# Altium schematic sheet power_supply.SchDoc
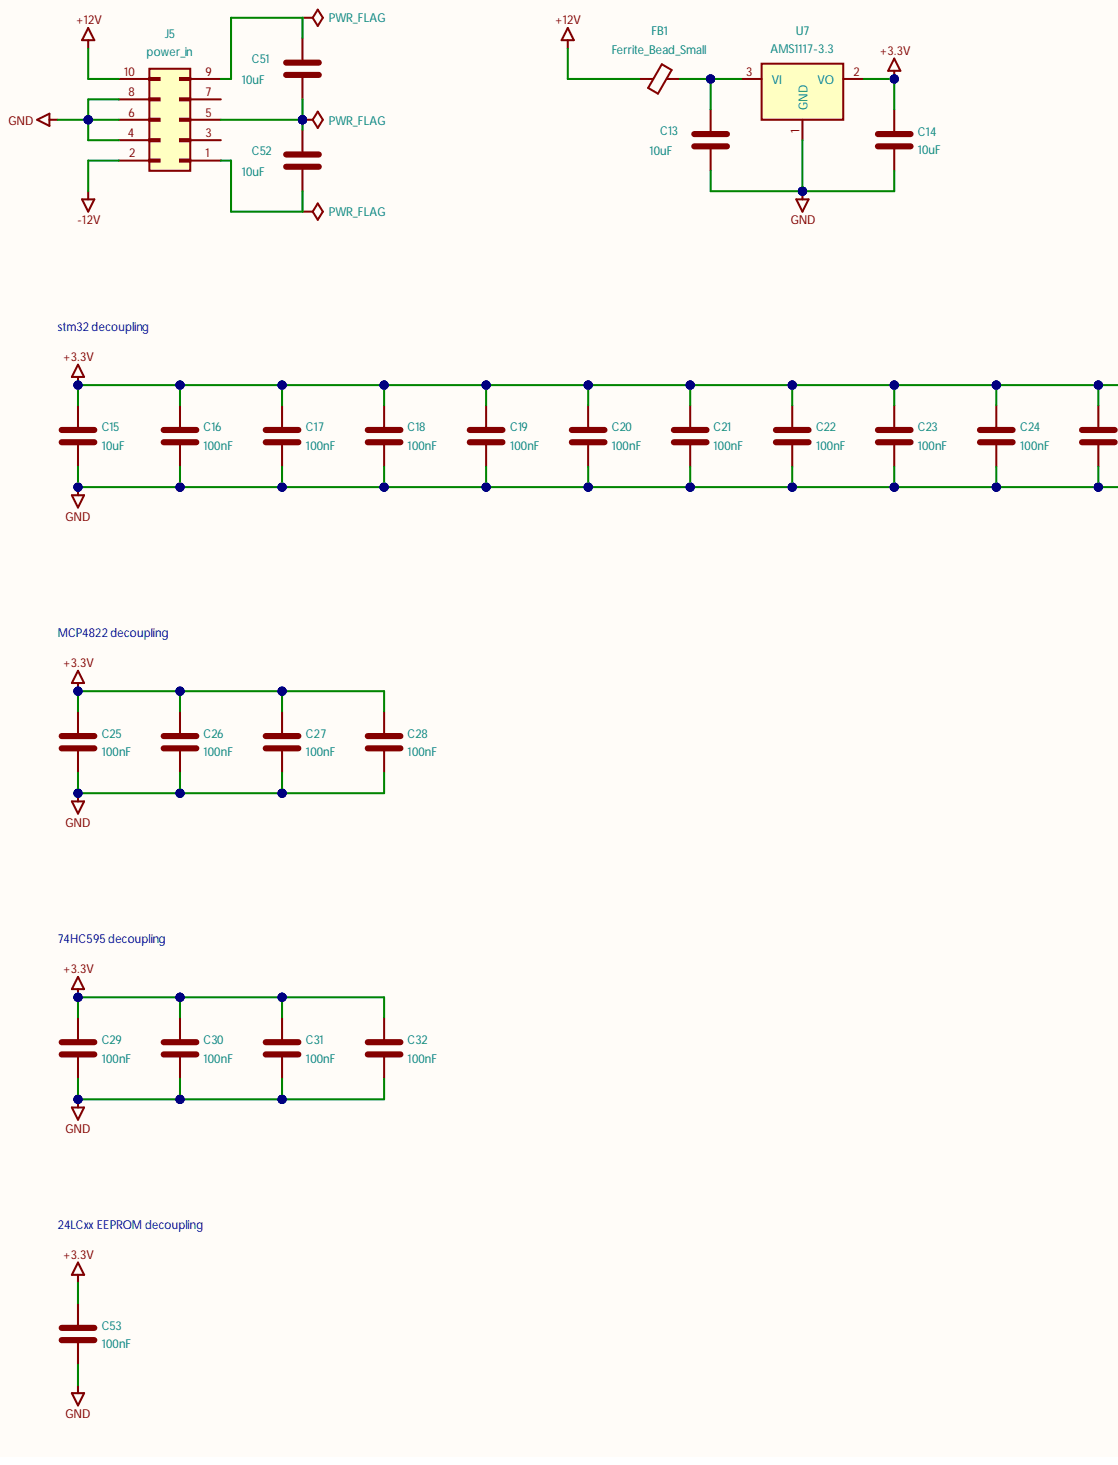
[diagram p0: power_supply.SchDoc, left]
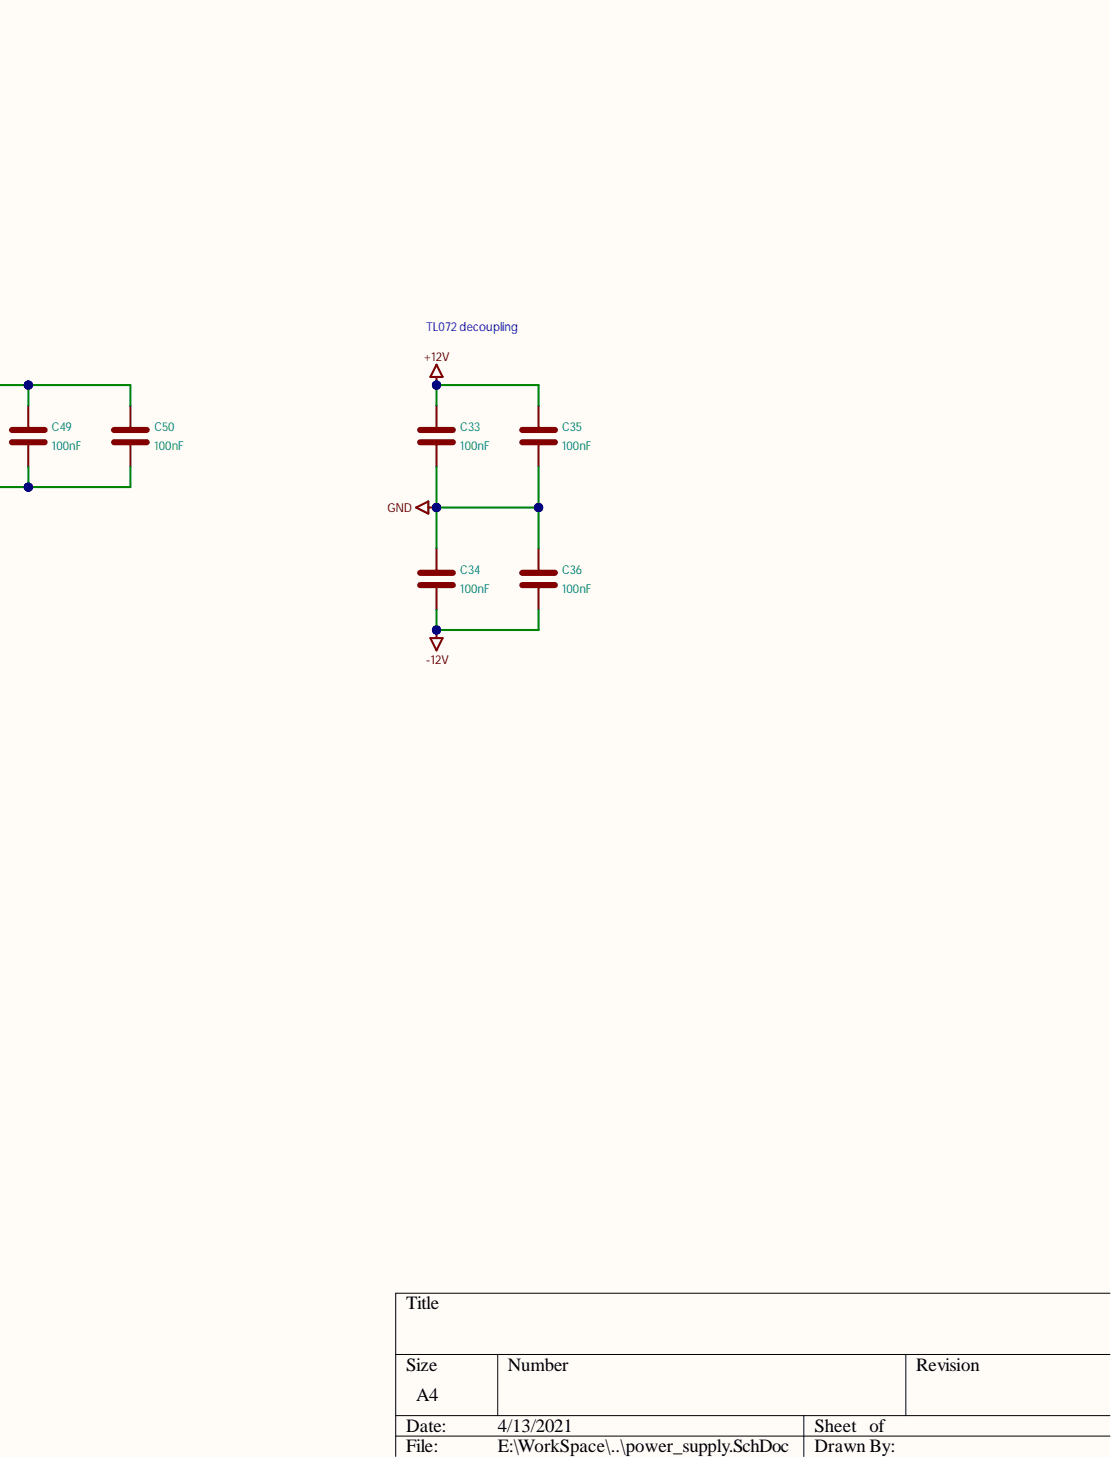
[diagram p1: power_supply.SchDoc, right]

## Parts
C13  10uF  [Capacitor_SMD:C_1206_3216Metric]
C14  10uF  [Capacitor_SMD:C_0805_2012Metric]
C15  10uF  [Capacitor_SMD:C_0805_2012Metric]
C16  100nF  [Capacitor_SMD:C_0402_1005Metric]
C17  100nF  [Capacitor_SMD:C_0402_1005Metric]
C18  100nF  [Capacitor_SMD:C_0402_1005Metric]
C19  100nF  [Capacitor_SMD:C_0402_1005Metric]
C20  100nF  [Capacitor_SMD:C_0402_1005Metric]
C21  100nF  [Capacitor_SMD:C_0402_1005Metric]
C22  100nF  [Capacitor_SMD:C_0402_1005Metric]
C23  100nF  [Capacitor_SMD:C_0402_1005Metric]
C24  100nF  [Capacitor_SMD:C_0402_1005Metric]
C25  100nF  [Capacitor_SMD:C_0402_1005Metric]
C26  100nF  [Capacitor_SMD:C_0402_1005Metric]
C27  100nF  [Capacitor_SMD:C_0402_1005Metric]
C28  100nF  [Capacitor_SMD:C_0402_1005Metric]
C29  100nF  [Capacitor_SMD:C_0402_1005Metric]
C30  100nF  [Capacitor_SMD:C_0402_1005Metric]
C31  100nF  [Capacitor_SMD:C_0402_1005Metric]
C32  100nF  [Capacitor_SMD:C_0402_1005Metric]
C33  100nF  [Capacitor_SMD:C_0603_1608Metric]
C34  100nF  [Capacitor_SMD:C_0603_1608Metric]
C35  100nF  [Capacitor_SMD:C_0603_1608Metric]
C36  100nF  [Capacitor_SMD:C_0603_1608Metric]
C49  100nF  [Capacitor_SMD:C_0402_1005Metric]
C50  100nF  [Capacitor_SMD:C_0402_1005Metric]
C51  10uF  [Capacitor_SMD:C_1206_3216Metric]
C52  10uF  [Capacitor_SMD:C_1206_3216Metric]
C53  100nF  [Capacitor_SMD:C_0402_1005Metric]
FB1  Ferrite_Bead_Small  [Inductor_SMD:L_0805_2012Metric]
J5  power_in  [Connector_PinHeader_2.54mm:PinHeader_2x05_P2.54mm_Vertical]
U7  AMS1117-3.3  [Package_TO_SOT_SMD:SOT-223-3_TabPin2]

## Nets
+3.3V: C14.1 C15.1 C16.1 C17.1 C18.1 C19.1 C20.1 C21.1 C22.1 C23.1 C24.1 C25.1 C26.1 C27.1 C28.1 C29.1 C30.1 C31.1 C32.1 C49.1 C50.1 C53.1 U7.2
+12V: C33.1 C35.1 FB1.2 J5.10
-12V: C34.2 C36.2 J5.2
GND: C13.2 C14.2 C15.2 C16.2 C17.2 C18.2 C19.2 C20.2 C21.2 C22.2 C23.2 C24.2 C25.2 C26.2 C27.2 C28.2 C29.2 C30.2 C31.2 C32.2 C33.2 C34.1 C35.2 C36.1 C49.2 C50.2 C53.2 J5.4 J5.6 J5.8 U7.1
NetC13_1: C13.1 FB1.1 U7.3
NetC51_1: C51.1 J5.9
NetC51_2: C51.2 C52.1 J5.5
NetC52_2: C52.2 J5.1

Not connected: J5 3 7

## Library data of the parts
C13-C36 C49-C53 = C: Component Kind: Standard; Library Name: Device
  C13-C15 C51 C52: Value: 10uF
  C16-C36 C49 C50 C53: Value: 100nF
J5 = Conn_02x05_Odd_Even: Component Kind: Standard; Library Name: Connector_Generic; Value: power_in
FB1 = Ferrite_Bead_Small: Component Kind: Standard; Library Name: Device; Value: Ferrite_Bead_Small
U7 = AMS1117-3.3: Component Kind: Standard; Library Name: Regulator_Linear; Value: AMS1117-3.3
